# Altium schematic sheet RF.SchDoc(RF)
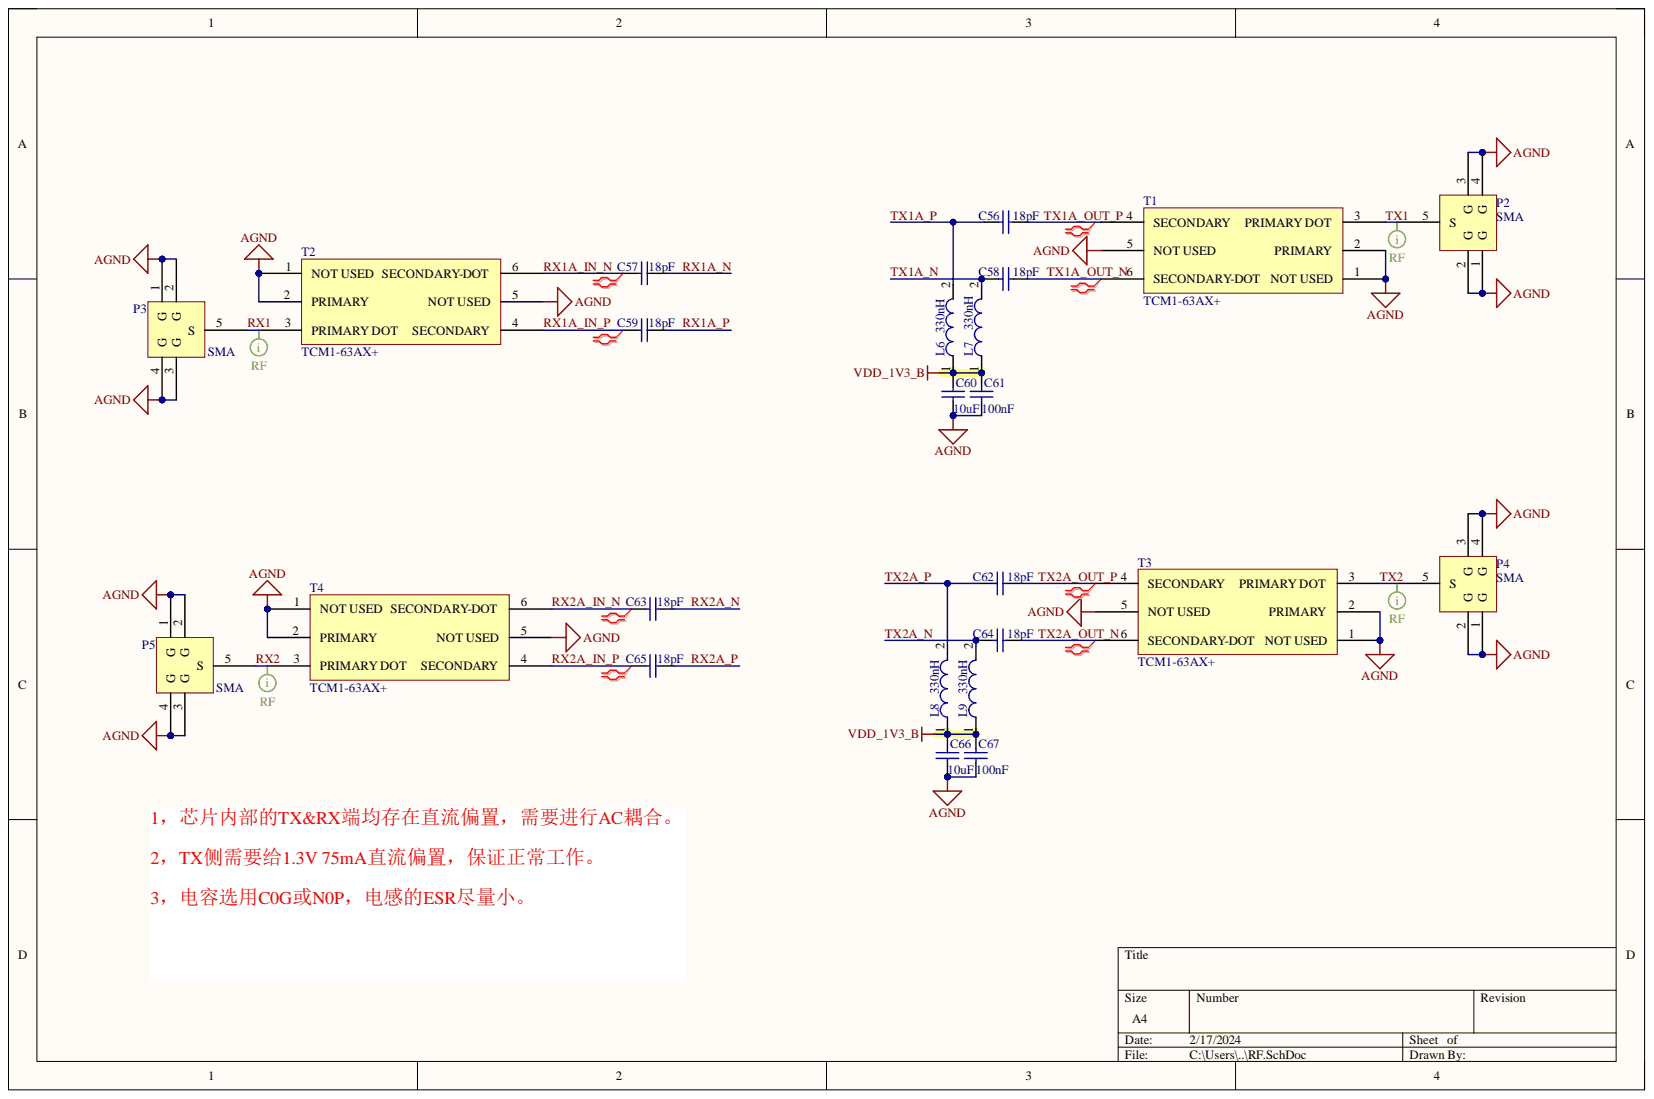
[diagram p0: RF.SchDoc]

## Parts
C56  18pF  [C0402]
C57  18pF  [C0402]
C58  18pF  [C0402]
C59  18pF  [C0402]
C60  10uF  [C0402]
C61  100nF  [C0402]
C62  18pF  [C0402]
C63  18pF  [C0402]
C64  18pF  [C0402]
C65  18pF  [C0402]
C66  10uF  [C0402]
C67  100nF  [C0402]
L6  330nH  [L0402]
L7  330nH  [L0402]
L8  330nH  [L0402]
L9  330nH  [L0402]
P2  SMA  [SMA_SMD]
P3  SMA  [SMA_SMD]
P4  SMA  [SMA_SMD]
P5  SMA  [SMA_SMD]
T1  TCM1-63AX+  [TCM1-63AX+]
T2  TCM1-63AX+  [TCM1-63AX+]
T3  TCM1-63AX+  [TCM1-63AX+]
T4  TCM1-63AX+  [TCM1-63AX+]

## Nets
AGND: C60.1 C61.1 C66.1 C67.1 P2.1(G) P2.2(G) P2.3(G) P2.4(G) P3.1(G) P3.2(G) P3.3(G) P3.4(G) P4.1(G) P4.2(G) P4.3(G) P4.4(G) P5.1(G) P5.2(G) P5.3(G) P5.4(G) T1.1(NOT_USED) T1.2(PRIMARY) T1.5(NOT_USED) T2.1(NOT_USED) T2.2(PRIMARY) T2.5(NOT_USED) T3.1(NOT_USED) T3.2(PRIMARY) T3.5(NOT_USED) T4.1(NOT_USED) T4.2(PRIMARY) T4.5(NOT_USED)
VDD_1V3_B: C60.2 C61.2 C66.2 C67.2 L6.1 L7.1 L8.1 L9.1
RX1: P3.5(S) T2.3(PRIMARY_DOT)
RX1A_IN_N: C57.1 T2.6(SECONDARY-DOT)
RX1A_IN_P: C59.1 T2.4(SECONDARY)
RX1A_N: C57.2
RX1A_P: C59.2
RX2: P5.5(S) T4.3(PRIMARY_DOT)
RX2A_IN_N: C63.1 T4.6(SECONDARY-DOT)
RX2A_IN_P: C65.1 T4.4(SECONDARY)
RX2A_N: C63.2
RX2A_P: C65.2
TX1: P2.5(S) T1.3(PRIMARY_DOT)
TX1A_N: C58.1 L7.2
TX1A_OUT_N: C58.2 T1.6(SECONDARY-DOT)
TX1A_OUT_P: C56.2 T1.4(SECONDARY)
TX1A_P: C56.1 L6.2
TX2: P4.5(S) T3.3(PRIMARY_DOT)
TX2A_N: C64.1 L9.2
TX2A_OUT_N: C64.2 T3.6(SECONDARY-DOT)
TX2A_OUT_P: C62.2 T3.4(SECONDARY)
TX2A_P: C62.1 L8.2

## Library data of the parts
C56-C67 = Cap_NP: CAP no polarity; Component Kind: Standard; Library Name: Common.SchLib
L6-L9 = Inductor: Component Kind: Standard; Description: Inductor; Library Name: Common.SchLib
P2-P5 = SMA: SMA RF Connector; Component Kind: Standard; Library Name: Common.SchLib
T1-T4 = TCM1-63AX+: Balun; Component Kind: Standard; Library Name: ZYNQ_SDR.SCHLIB
